# Altium schematic sheet Power.SchDoc
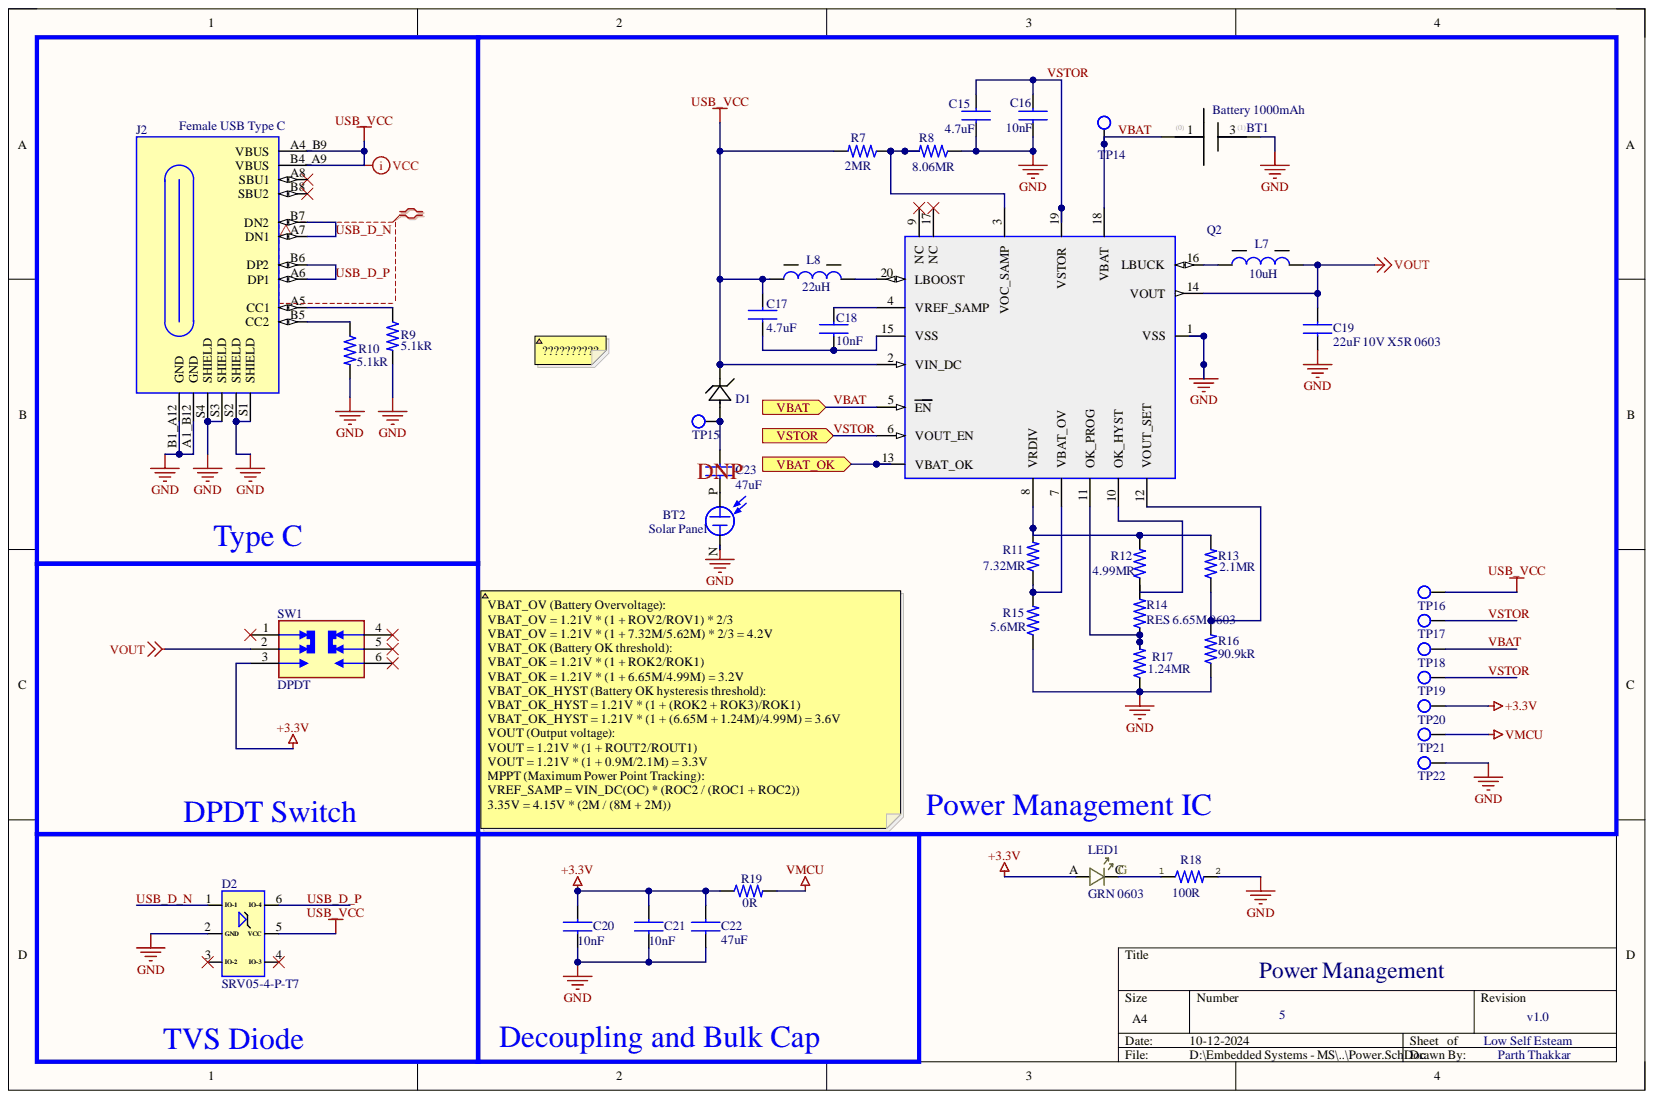
[diagram p0: Power.SchDoc]

## Parts
BT1  Battery 1000mAh  [Battery]
BT2  Solar Panel  [Solar panel]
C15  value 4.7uF  [Capacitor 0603]  (hidden comment: CAP 4.7uF 25V 0603)
C16  value 10nF  [Capacitor 0603]  (hidden comment: CAP 10nF 100V 0603)
C17  value 4.7uF  [Capacitor 0603]  (hidden comment: CAP 4.7uF 25V 0603)
C18  value 10nF  [Capacitor 0603]  (hidden comment: CAP 10nF 100V 0603)
C19  22uF 10V X5R 0603  value 22uF  [Capacitor 0603]
C20  value 10nF  [Capacitor 0603]  (hidden comment: CAP 0.1uF 100V 0603)
C21  value 10nF  [Capacitor 0603]  (hidden comment: CAP 0.1uF 100V 0603)
C22  value 47uF  [Capacitor 0805]  (hidden comment: CAP 47uF 10V 0805)
C23  value 47uF  [CAPACITOR 0805]  (hidden comment: CAP 47uF 10V 0805)
D1  Diode Schottky  [Diode Schottky]  (value not drawn)
D2  SRV05-4-P-T7  [TVS]
J2  Female USB Type C  [Type C]
L7  Inductance-Nom (L): 10uH  [IND 10uH (1x3.2)]  (hidden comment: IND 10uH 1111)
L8  Inductance-Nom (L): 22uH  [IND 22uH (1.6x4.3)]  (hidden comment: IND 22uH 1515)
LED1  GRN 0603  [LED 0603]
Q2  BQ25570RGRR  [BQ25570RGRR]  (value not drawn)
R7  value 2MR  [Resistor 0603]  (hidden comment: RES 2M 0603)
R8  value 8.06MR  [Resistor 0603]  (hidden comment: RES 8M 0603)
R9  value 5.1kR  [Resistor 0603]  (hidden comment: RES 5.1K 0603)
R10  value 5.1kR  [Resistor 0603]  (hidden comment: RES 5.1K 0603)
R11  value 7.32MR  [Resistor 0603]  (hidden comment: RES 7.32M 0603)
R12  value 4.99MR  [Resistor 0603]  (hidden comment: RES 4.99M 0603)
R13  value 2.1MR  [Resistor 0603]  (hidden comment: RES 2.1M 0603)
R14  RES 6.65M 0603  [Resistor 0603]
R15  value 5.6MR  [Resistor 0603]  (hidden comment: RES 5.62M 0603)
R16  value 90.9kR  Case/Package: 0603  [Resistor 0603]  (hidden comment: RES 0.9M 0603)
R17  value 1.24MR  [Resistor 0603]  (hidden comment: RES 1.24M 0603)
R18  value 100R  [Resistor 0603]  (hidden comment: RES 100R 0603)
R19  value 0R  [Resistor 0402]  (hidden comment: RES 0R 0402)
SW1  DPDT  [DPDT]
TP14  Test Point  [Test point]  (value not drawn)  (no BOM)
TP15  Test Point  [Test point]  (value not drawn)  (no BOM)
TP16  Test point  [Test Point]  (value not drawn)  (no BOM)
TP17  Test point  [Test Point]  (value not drawn)  (no BOM)
TP18  Test point  [Test Point]  (value not drawn)  (no BOM)
TP19  Test point  [Test Point]  (value not drawn)  (no BOM)
TP20  Test point  [Test Point]  (value not drawn)  (no BOM)
TP21  Test point  [Test Point]  (value not drawn)  (no BOM)
TP22  Test point  [Test Point]  (value not drawn)  (no BOM)

## Ports
VBAT, VBAT_OK, VSTOR

## Nets
+3.3V: C20.1 C21.1 C22.1 LED1.A R19.1 SW1.3 TP20.1
GND: BT1.1 BT2.N C15.2 C16.2 C19.2 C20.2 C21.2 C22.2 D2.2(GND) J2.A1_B12(GND) J2.B1_A12(GND) J2.S1(SHIELD) J2.S2(SHIELD) J2.S3(SHIELD) J2.S4(SHIELD) Q2.1(VSS) R8.1 R9.2 R10.2 R15.1 R16.1 R17.1 R18.2 TP22.1
USB_VCC: C17.1 D1.1 D2.5(VCC) J2.A4_B9(VBUS) J2.B4_A9(VBUS) L8.1 Q2.2(VIN_DC) R7.2 TP16.1
VMCU: R19.2 TP21.1
VOUT: C19.1 L7.2 Q2.14(VOUT) SW1.2
USB_D_N: D2.1(IO-1) J2.A7(DN1) J2.B7(DN2)
USB_D_P: D2.6(IO-4) J2.A6(DP1) J2.B6(DP2)
VBAT: BT1.0 Q2.5(EN) Q2.18(VBAT) TP14.1 TP18.1 ; port VBAT
VBAT_OK: Q2.13(VBAT_OK) ; port VBAT_OK
VSTOR: C15.1 C16.1 Q2.6(VOUT_EN) Q2.19(VSTOR) TP17.1 TP19.1 ; port VSTOR
NetBT2_P: BT2.P C23.2
NetC17_2: C17.2 C18.1 Q2.15(VSS)
NetC18_2: C18.2 Q2.4(VREF_SAMP)
NetC23_1: C23.1 D1.2 TP15.1
NetD2_3: D2.3(IO-2)
NetD2_4: D2.4(IO-3)
NetJ2_A5: J2.A5(CC1) R9.1
NetJ2_A8: J2.A8(SBU1)
NetJ2_B5: J2.B5(CC2) R10.1
NetJ2_B8: J2.B8(SBU2)
NetL7_1: L7.1 Q2.16(LBUCK)
NetL8_2: L8.2 Q2.20(LBOOST)
NetLED1_C: LED1.C R18.1
NetQ2_3: Q2.3(VOC_SAMP) R7.1 R8.2
NetQ2_7: Q2.7(VBAT_OV) R11.1 R15.2
NetQ2_8: Q2.8(VRDIV) R11.2 R12.2 R13.2
NetQ2_9: Q2.9(NC)
NetQ2_10: Q2.10(OK_HYST) R12.1
NetQ2_11: Q2.11(OK_PROG) R14.1 R17.2
NetQ2_12: Q2.12(VOUT_SET) R13.1 R16.2
NetQ2_17: Q2.17(NC)
NetR14_2: R14.2
NetSW1_1: SW1.1
NetSW1_4: SW1.4
NetSW1_5: SW1.5
NetSW1_6: SW1.6
